# Altium schematic sheet 19-FPGA_1.SchDoc(19-FPGA_1)
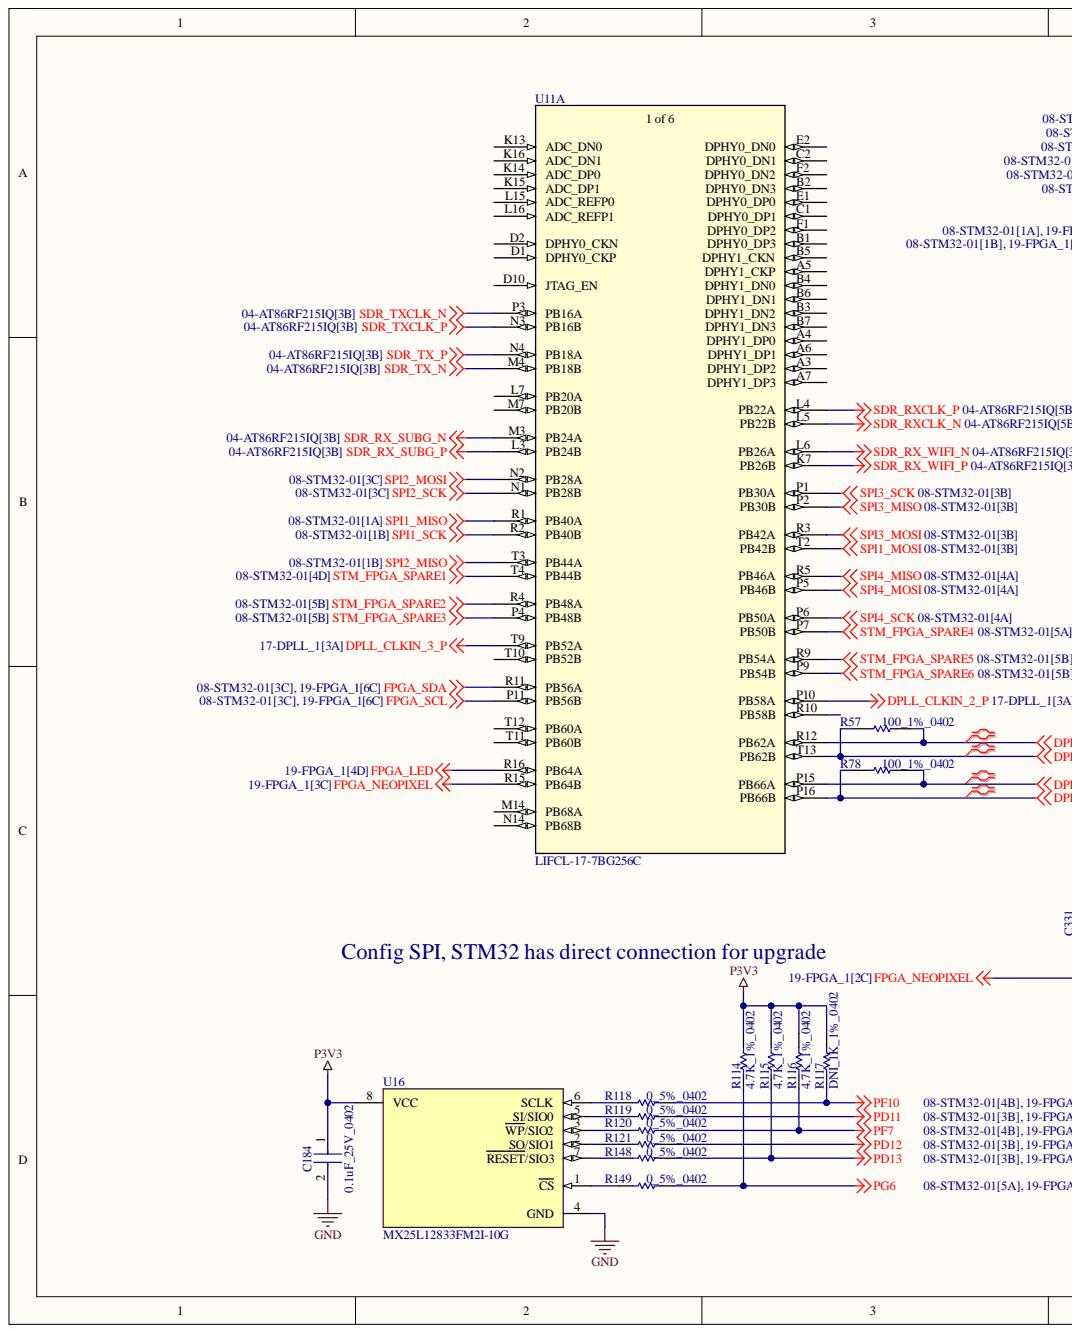
[diagram p0: 19-FPGA_1.SchDoc, left]
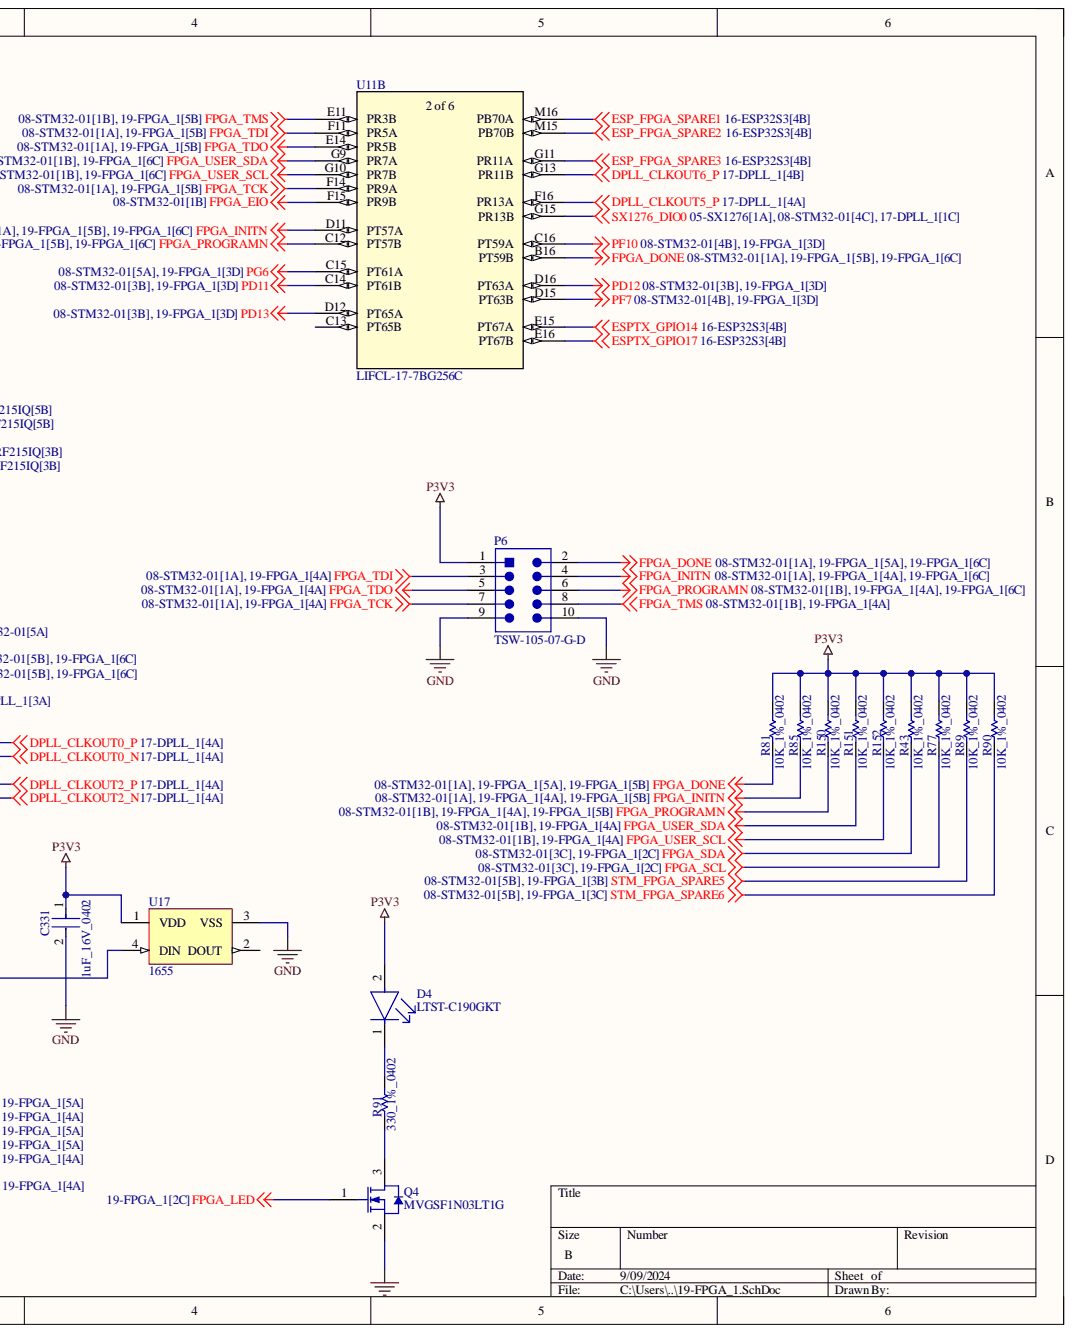
[diagram p1: 19-FPGA_1.SchDoc, right]

## Parts
C184  0.1uF_25V_0402  [FP-0402-L_1_0_1-W_0_5_0_1-IPC_C]
C331  1uF_16V_0402  [FP-0402-L_1_0_0_05-W_0_5-IPC_A]
D4  LTST-C190GKT  [FP-LTST-C190GKT-MFG]
P6  TSW-105-07-G-D  [SMTC-TSW-105-07-G-D_V]
Q4  MVGSF1N03LT1G  [ONSC-SOT-23-3-318-08_V]
R43  10K_1%_0402  [RESC1005X40X25NL10T10]
R57  100_1%_0402  [RESC1005X40X25NL05T10]
R77  10K_1%_0402  [RESC1005X40X25NL10T10]
R78  100_1%_0402  [RESC1005X40X25NL05T10]
R81  10K_1%_0402  [RESC1005X40X25NL10T10]
R85  10K_1%_0402  [RESC1005X40X25NL10T10]
R89  10K_1%_0402  [RESC1005X40X25NL10T10]
R90  10K_1%_0402  [RESC1005X40X25NL10T10]
R91  330_1%_0402  [RESC1005X40X25NL10T10]
R114  4.7K_1%_0402  [RESC1005X40X25NL10T10]
R115  4.7K_1%_0402  [RESC1005X40X25NL10T10]
R116  4.7K_1%_0402  [RESC1005X40X25NL10T10]
R117  DNI_1K_1%_0402  [RESC1005X40X25LL05T10]  (no BOM)
R118  0_5%_0402  [RESC1005X40X25NL05T10]
R119  0_5%_0402  [RESC1005X40X25NL05T10]
R120  0_5%_0402  [RESC1005X40X25NL05T10]
R121  0_5%_0402  [RESC1005X40X25NL05T10]
R148  0_5%_0402  [RESC1005X40X25NL05T10]
R149  0_5%_0402  [RESC1005X40X25NL05T10]
R150  10K_1%_0402  [RESC1005X40X25NL10T10]
R151  10K_1%_0402  [RESC1005X40X25NL10T10]
R152  10K_1%_0402  [RESC1005X40X25NL10T10]
U11  LIFCL-17-7BG256C  [caBGA256_LAT]  (parts A,B)
U16  MX25L12833FM2I-10G  [FP-MX25L12833FM2I-10G-MFG]
U17  1655  [ADA-RGB-1655_V]

## Nets
DPLL_CLKIN_2_P: U11.P10(PB58A)
DPLL_CLKIN_3_P: U11.T9(PB52A)
DPLL_CLKOUT0_N: R57.1 U11.T13(PB62B)
DPLL_CLKOUT0_P: R57.2 U11.R12(PB62A)
DPLL_CLKOUT2_N: R78.1 U11.P16(PB66B)
DPLL_CLKOUT2_P: R78.2 U11.P15(PB66A)
DPLL_CLKOUT5_P: U11.F16(PR13A)
DPLL_CLKOUT6_P: U11.G13(PR11B)
ESP_FPGA_SPARE1: U11.M16(PB70A)
ESP_FPGA_SPARE2: U11.M15(PB70B)
ESP_FPGA_SPARE3: U11.G11(PR11A)
ESPTX_GPIO14: U11.E15(PT67A)
ESPTX_GPIO17: U11.E16(PT67B)
FPGA_DONE: P6.2 R81.1 U11.B16(PT59B)
FPGA_EIO: U11.F15(PR9B)
FPGA_INITN: P6.4 R85.1 U11.D11(PT57A)
FPGA_LED: Q4.1 U11.R16(PB64A)
FPGA_NEOPIXEL: U11.R15(PB64B) U17.4(DIN)
FPGA_PROGRAMN: P6.6 R150.1 U11.C12(PT57B)
FPGA_SCL: R77.1 U11.P11(PB56B)
FPGA_SDA: R43.1 U11.R11(PB56A)
FPGA_TCK: P6.7 U11.F14(PR9A)
FPGA_TDI: P6.3 U11.F11(PR5A)
FPGA_TDO: P6.5 U11.E14(PR5B)
FPGA_TMS: P6.8 U11.E11(PR3B)
FPGA_USER_SCL: R152.1 U11.G10(PR7B)
FPGA_USER_SDA: R151.1 U11.G9(PR7A)
GND: C184.2 C331.2 P6.9 P6.10 Q4.2 U16.4(GND) U17.3(VSS)
P3V3: C184.1 C331.1 D4.2 P6.1 R43.2 R77.2 R81.2 R85.2 R89.2 R90.2 R114.2 R115.2 R116.2 R117.2 R150.2 R151.2 R152.2 U16.8(VCC) U17.1(VDD)
PD11: R119.2 U11.C14(PT61B)
PD12: R121.2 U11.D16(PT63A)
PD13: R115.1 R148.2 U11.D12(PT65A)
PF7: R116.1 R120.2 U11.D15(PT63B)
PF10: R117.1 R118.2 U11.C16(PT59A)
PG6: R114.1 R149.2 U11.C15(PT61A)
SDR_RX_SUBG_N: U11.M3(PB24A)
SDR_RX_SUBG_P: U11.L3(PB24B)
SDR_RX_WIFI_N: U11.L6(PB26A)
SDR_RX_WIFI_P: U11.K7(PB26B)
SDR_RXCLK_N: U11.L5(PB22B)
SDR_RXCLK_P: U11.L4(PB22A)
SDR_TX_N: U11.M4(PB18B)
SDR_TX_P: U11.N4(PB18A)
SDR_TXCLK_N: U11.P3(PB16A)
SDR_TXCLK_P: U11.N3(PB16B)
SPI1_MISO: U11.R1(PB40A)
SPI1_MOSI: U11.T2(PB42B)
SPI1_SCK: U11.R2(PB40B)
SPI2_MISO: U11.T3(PB44A)
SPI2_MOSI: U11.N2(PB28A)
SPI2_SCK: U11.N1(PB28B)
SPI3_MISO: U11.P2(PB30B)
SPI3_MOSI: U11.R3(PB42A)
SPI3_SCK: U11.P1(PB30A)
SPI4_MISO: U11.R5(PB46A)
SPI4_MOSI: U11.P5(PB46B)
SPI4_SCK: U11.P6(PB50A)
STM_FPGA_SPARE1: U11.T4(PB44B)
STM_FPGA_SPARE2: U11.R4(PB48A)
STM_FPGA_SPARE3: U11.P4(PB48B)
STM_FPGA_SPARE4: U11.P7(PB50B)
STM_FPGA_SPARE5: R89.1 U11.R9(PB54A)
STM_FPGA_SPARE6: R90.1 U11.P9(PB54B)
SX1276_DIO0: U11.G15(PR13B)
NetD4_1: D4.1 R91.2
NetQ4_3: Q4.3 R91.1
NetR118_1: R118.1 U16.6(SCLK)
NetR119_1: R119.1 U16.5(SI/SIO0)
NetR120_1: R120.1 U16.3(WP/SIO2)
NetR121_1: R121.1 U16.2(SO/SIO1)
NetR148_1: R148.1 U16.7(RESET/SIO3)
NetR149_1: R149.1 U16.1(CS)

Not connected: U11 A3(DPHY1_DP2) A4(DPHY1_DP0) A5(DPHY1_CKP) A6(DPHY1_DP1) A7(DPHY1_DP3) B1(DPHY0_DP3) B2(DPHY0_DN3) B3(DPHY1_DN2) B4(DPHY1_DN0) B5(DPHY1_CKN) B6(DPHY1_DN1) B7(DPHY1_DN3) C1(DPHY0_DP1) C2(DPHY0_DN1) C13(PT65B) D1(DPHY0_CKP) D2(DPHY0_CKN) D10(JTAG_EN) E1(DPHY0_DP0) E2(DPHY0_DN0) F1(DPHY0_DP2) F2(DPHY0_DN2) K13(ADC_DN0) K14(ADC_DP0) K15(ADC_DP1) K16(ADC_DN1) L7(PB20A) L15(ADC_REFP0) L16(ADC_REFP1) M7(PB20B) M14(PB68A) N14(PB68B) R10(PB58B) T10(PB52B) T11(PB60B) T12(PB60A); U17 2(DOUT)

## Library data of the parts
C184 = CMP-2008-02519-2: General Purpose Ceramic Capacitor, 0402, 100nF, 10%, X7R, 15%, 25V; Capacitance: 100nF; Case Code (Imperial): 0402; Case Code (Metric): 1005; Case/Package: 0402; Component Kind: Standard; Construction: Flat; Depth: 0.5mm; Dielectric: X7R; Lead Free: Lead Free; Length: 1mm; Library Name: Altium Content Vault; Max Operating Temperature: 125°C; Min Operating Temperature: -55°C; Mount: Surface Mount; Number of Pins: 2; Package Quantity: 1; Packaging: Tape and Reel; Radiation Hardening: No; REACH SVHC: No SVHC; RoHS Compliant: Yes; Series: -; Termination: SMD/SMT; Thickness: 0.022inch; Tolerance: 10%; Voltage: 25V; Voltage Rating: 25V; Voltage Rating (DC): 25V; Width: 0.02inch
C331 = CMP-14477-000104-2: None; Capacitance: 1uF; Dielectric: X5R; Tolerance: 10%; Voltage Rating: 16V; Case Code (Imperial): 0402; Case Code (Metric): 1005; Case/Package: 0402; Component Kind: Standard; Construction: Flat; Depth: 0.5mm; Halogen Free: Halogen Free; Height: 0.5mm; Length: 1mm; Library Name: Altium Content Vault; Max Operating Temperature: 85°C; Min Operating Temperature: -55°C; Mount: Surface Mount; Number of Pins: 2; Package Quantity: 1; Packaging: Tape and Reel; Radiation Hardening: No; RoHS Compliant: Yes; Series: M; Termination: SMD/SMT; Thickness: 0.022inch; Voltage Rating (DC): 16V; Width: 0.02inch
D4 = CMP-22017-000005-2: LED GREEN CLEAR CHIP SMD; Dominant Wavelength: 569nm; Forward Current: 30mA; Forward Voltage: 2.1V; Lens Color: Colorless; Luminous Intensity: 6mcd; Number of Elements: 1; Power Dissipation: 100mW; Reverse Voltage: 5V; Test Current: 10mA; Viewing Angle: 130°; Voltage: 2V; Wavelength: 565nm; Case Code (Imperial): 0603; Case Code (Metric): 1608; Case/Package: 0603; Color: Green; Component Kind: Standard; Depth: 0.8mm; Height: 0.8mm; Illumination Color: Green; Lead Free: Lead Free; Length: 1.6mm; Lens Style: Clear; Lens Transparency: Clear; Library Name: Altium Content Vault; Max Operating Temperature: 85°C; Min Operating Temperature: -55°C; Mount: Surface Mount; Number of LEDs: 1; Number of Pins: 2; Package Quantity: 1; Packaging: Tape and Reel; Power Rating: 100mW; Radiation Hardening: No; Width: 0.8mm
P6 = CMP-2000-07330-1: Post Terminal Strip Assembly, Pitch 2.54 mm, 2 x 5 Position, Height 8.58 mm, Tail Length 2.54 mm, -55 to 125 degC, RoHS, Bulk; Manufacturer: Samtec-Inc.; Connector Type: Header,Unshrouded; Number of Positions: 10; Number of Positions Loaded: All; Pitch: 0.100"(2.54mm); Category: Connectors,Interconnects; Color: Black; Component Kind: Standard; Contact Finish: Gold; Contact Finish Thickness: 10µin(0.25µm); Contact Mating Length: 0.230"(5.84mm); Contact Type: MalePin; Datasheet URL: http://suddendocs.samtec.com/prints/tsw-xxx-xx-xxx-x-xx-xxx-mkt.pdf; Datasheet Version: Rev. DO, 08/1988; Family: RectangularConnectors-Headers,MalePins; Fastening Type: -; Features: -; Library Name: Altium Content Vault; Manufacturer URL: http://www.samtec.com/; Mounting Technology: ThroughHole; Mounting Type: ThroughHole; Number of Rows: 2; Package Description: Male Header, Pitch 2.54 mm, 2 x 5 Position, Height 8.58 mm, Tail Length 2.54 mm; Package Reference: TSW-105-07-G-D; Package URL: http://suddendocs.samtec.com/prints/tsw-xxx-xx-x-x-xx-xxx-footprint.pdf; Packaging: Bulk; Row Spacing: 0.100"(2.54mm); Series: TSW; Termination: Solder
Q4 = CMP-1058-00779-1: Power MOSFET, 30 V, 2.1 A, Single N-Channel, 3-Pin SOT-23, Pb-Free, Tape and Reel; Manufacturer: On Semiconductor; Channel Polarity: N Channel; Ciss Typ (pF): 140; Coss Typ (pF): 100; Crss Typ (pF): 40; ID Max (A): 2.1; V(BR)DSS Min (V): 30; VGS Max (V): 20; VGS(th) Max (V): 2.4; Component Kind: Standard; ComponentLink1Description: Manufacturer URL; ComponentLink1URL: http://www.onsemi.com/; ComponentLink2Description: Datasheet; ComponentLink2URL: http://www.onsemi.cn/pub_link/Collateral/MGSF1N03LT1-D.PDF; ComponentLink3Description: Package Specification; ComponentLink3URL: http://www.onsemi.cn/pub_link/Collateral/318-08.PDF; Configuration: Single; DatasheetVersion: Rev. 10; Library Name: Altium Content Vault; Mounting Technology: Surface Mount; Package Type: SOT-23-3; PackageDescription: 3-Pin Small Outline Transistor, Body 2.92 x 1.3 mm, Pitch 0.95 mm; PackageReference: SOT-23-3-318-08; PackageVersion: Rev. AP, 11/2009; Packing: Tape and Reel; PartNumber: MVGSF1N03LT1G; RoHS: Pb-Free
R43 R77 R81 R85 R89 R90 R150-R152 = CMP-2002-04694-1: Manufacturer: Bourns; Part Number: CR0402-FX-1002GLF; Component Kind: Standard; Library Name: Altium Content Vault
R57 R78 = CMP-2002-07853-1: Manufacturer: Yageo; Part Number: RC0402FR-13100RL; Component Kind: Standard; Library Name: Altium Content Vault
R91 = CMP-2002-05853-1: Manufacturer: Panasonic; Part Number: ERA-2AED331X; Component Kind: Standard; Library Name: Altium Content Vault
R114-R116 = CMP-2002-05217-1: Manufacturer: Bourns; Part Number: CR0402-FX-4701GLF; Component Kind: Standard; Library Name: Altium Content Vault
R117 = CMP-2002-03045-1: Manufacturer: Vishay Dale; Part Number: CRCW04021K00FKEDHP; Library Name: Altium Content Vault
R118-R121 R148 R149 = CMP-2002-06457-1: Manufacturer: Panasonic; Part Number: ERJ-U020R00X; Component Kind: Standard; Library Name: Altium Content Vault
U11 = LIFCL-17-7BG256C: No Description Available; Mfr_Name: Lattice; Component Kind: Standard; Library Name: LIFCL-17-7BG256C.SchLib; RefDes: RefDes; Type: Type
U16 = CMP-105207-000006-1: IC FLASH 128MBIT SPI/QUAD 8SOP; Component Kind: Standard; Library Name: Altium Content Vault; RoHS Compliant: Yes
U17 = CMP-2000-05026-1: Intelligent Control LED Integrated Light Source, 3.5 to 5.3 V, -25 to 80 degC, 4-Pin SMD, RoHS, Tape and Reel; Manufacturer: Adafruit-Industries-LLC; Configuration: Discrete; Number of LEDs: 10; Utilized LED: WS2812(5050); Category: Optoelectronics; Color: Red,Green,Blue(RGB); Component Kind: Standard; Controller Type: -; Datasheet URL: http://datasheet.octopart.com/ADA1655-Adafruit-Industries-datasheet-32028139.pdf; Datasheet Version: May-13; Family: Addressable,Specialty; Interface: -; Library Name: Altium Content Vault; Manufacturer URL: https://www.adafruit.com/; Mounting Type: SurfaceMount; Package Description: 4-Pin Surface Mount Device, Body 5 x 5 mm; Package Reference: 1655; Series: Neopixel; Size / Dimension: 5.00mmLx5.00mmW
